# Altium schematic sheet SD.SchDoc(SD)
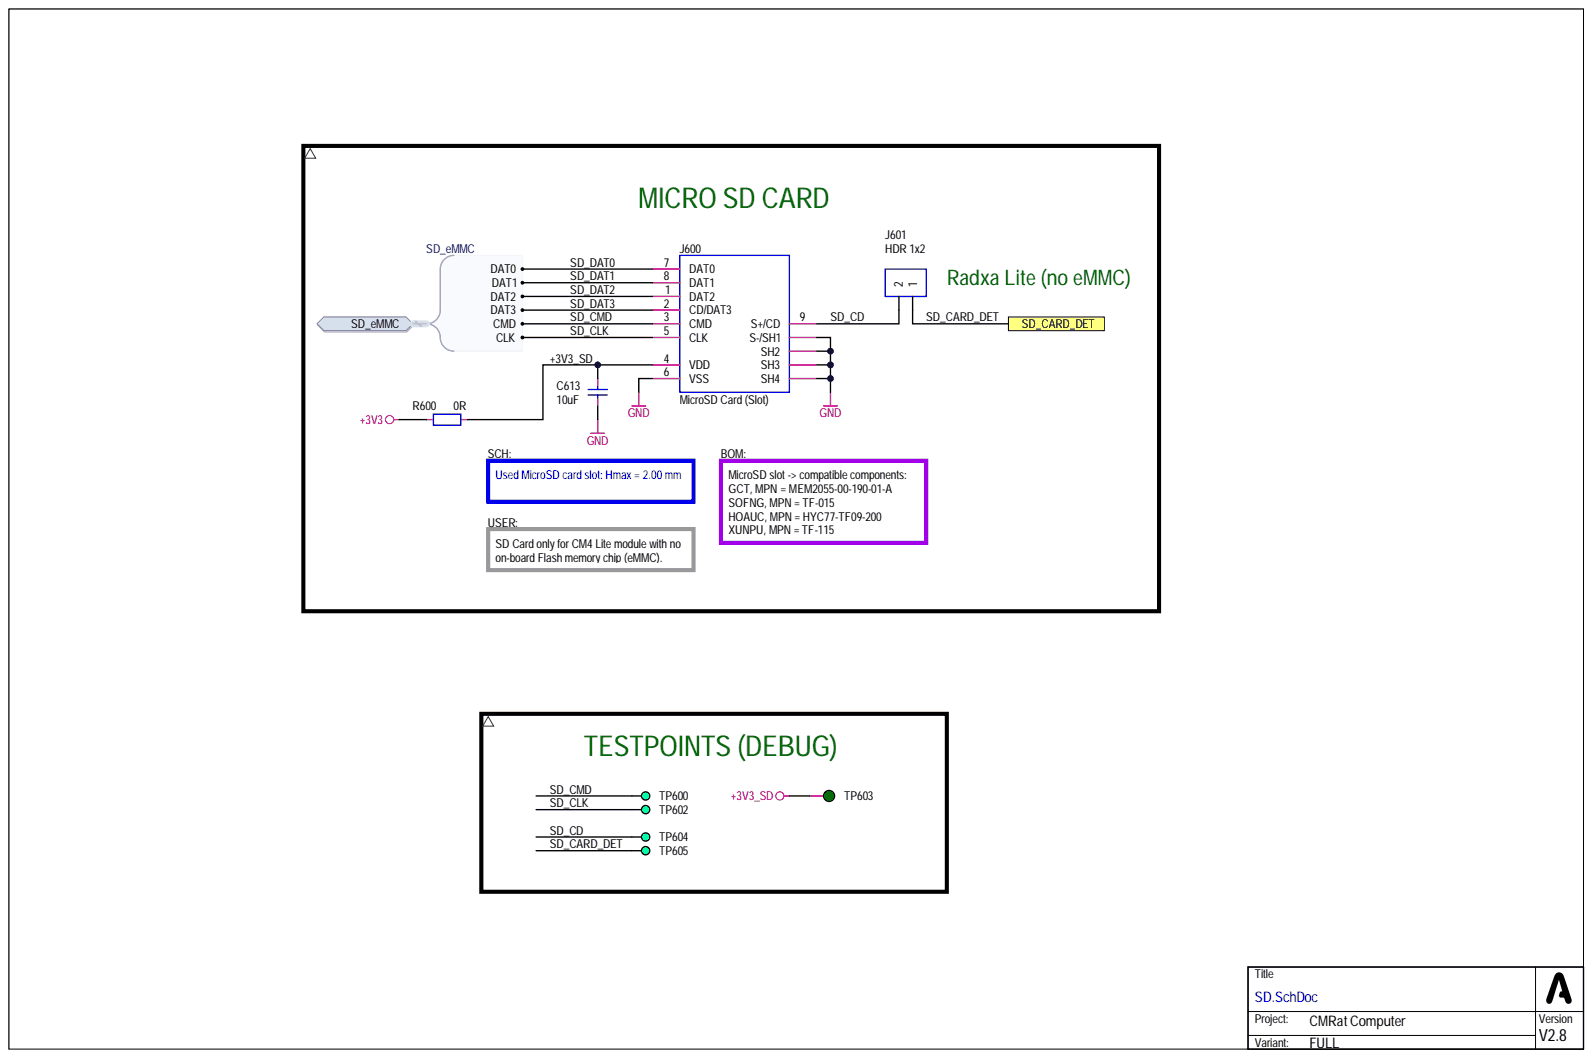
[diagram p0: SD.SchDoc]

## Parts
C613  10uF  [CAP_0603_R]
J600  MicroSD Card (Slot)  Component Subtype: MicroSD Card  [CON_GCT_MEM2055_R]
J601  HDR 1x2  [CON_HEADER_TMV_1X2_P254H857]
R600  0R  [RES_0603_R]
TB?  =Value  (no BOM)
TP600  DEBUG  [PCB_TP_DBG_50]  (value not drawn)  (no BOM)
TP602  DEBUG  [PCB_TP_DBG_50]  (value not drawn)  (no BOM)
TP603  ICT  [PCB_TP_ICT_100]  (value not drawn)  (no BOM)
TP604  DEBUG  [PCB_TP_DBG_50]  (value not drawn)  (no BOM)
TP605  DEBUG  [PCB_TP_DBG_50]  (value not drawn)  (no BOM)

## Ports
SD_CARD_DET, SD_eMMC, SD_eMMC.CLK, SD_eMMC.CMD, SD_eMMC.DAT0, SD_eMMC.DAT1, SD_eMMC.DAT2, SD_eMMC.DAT3

## Nets
+3V3: R600.2
GND: C613.1 J600.6(VSS) J600.SH1(S-/SH1) J600.SH2 J600.SH3 J600.SH4
+3V3_SD: C613.2 J600.4(VDD) R600.1 TP603.1
SD_CARD_DET: J601.1 TP605.1 ; port SD_CARD_DET
SD_CD: J600.9(S+/CD) J601.2 TP604.1
SD_CLK: J600.5(CLK) TP602.1 ; port SD_eMMC
SD_CMD: J600.3(CMD) TP600.1 ; port SD_eMMC
SD_DAT0: J600.7(DAT0) ; port SD_eMMC
SD_DAT1: J600.8(DAT1) ; port SD_eMMC
SD_DAT2: J600.1(DAT2) ; port SD_eMMC
SD_DAT3: J600.2(CD/DAT3) ; port SD_eMMC

## Library data of the parts
C613 = CAP-0023: CAP SMD 10u/10V ±10% 10V X5R (0603); Manufacturer: Samsung Electro-Mechanics; MPN: CL10A106KP8NNNC; Component Subtype: MLCC; Database Table Name: CAPACITOR$; Package (Case): 0603; Component Kind: Standard; Component Type: Capacitor; Mounting Type: SMD; Value: 10uF; Library Name: MirFol_db_local.DbLib
J600 = CON_GCT_MEM2055: Connector; MicroSD Card Connector;; Manufacturer: TF-015; Package (Case): SMD; Component Kind: Standard; Component Type: Card Socket; Mounting Type: SMD; MPN: TF-015; Value: MicroSD Card (Slot); Library Name: MirFol_lib.SchLib
J601 = ITEM_J10014: CONN THT / HEADER / PITCH 2,54mm / VERTICAL / (2 PIN); Manufacturer: Ckmtw(Shenzhen Cankemeng); MPN: B-2100S02P-A110; Component Subtype: Pin Header; Database Table Name: CONNECTOR$; Component Kind: Standard; Component Type: Connector; Library Name: DB_DBLIB.DbLib; Mounting Type: THT; Package (Case): THT; Value: HDR 1x2
R600 = RES-0054: Resistor; Thick Film; 0R; SMD; 0603 (1608);; Manufacturer: UNI-ROYAL(Uniroyal Elec); MPN: 0603WAF0000T5E; Database Table Name: RESISTOR$; Package (Case): 0603; Component Kind: Standard; Component Subtype: Thick Film; Component Type: Resistor; Mounting Type: SMD; Value: 0R; Library Name: MirFol_db_local.DbLib
TB? = SCH_TITLE_BLOCK_SIMPLE_NODATE: Library Name: Symbols_NO_BOM.SchLib
TP600 TP602 TP604 TP605 = PCB_TP_DBG: PCB Marking; Test Point (Debug);; Component Type: Testpoint; Value: DEBUG; Library Name: MirFol_lib.SchLib
TP603 = PCB_TP_ICT: Test Point (ICT); Component Type: Testpoint (for ICT); Mounting Type: Non component; Value: ICT; Library Name: MirFol_lib.SchLib
